# Altium schematic sheet Inputs.SchDoc(Inputs)
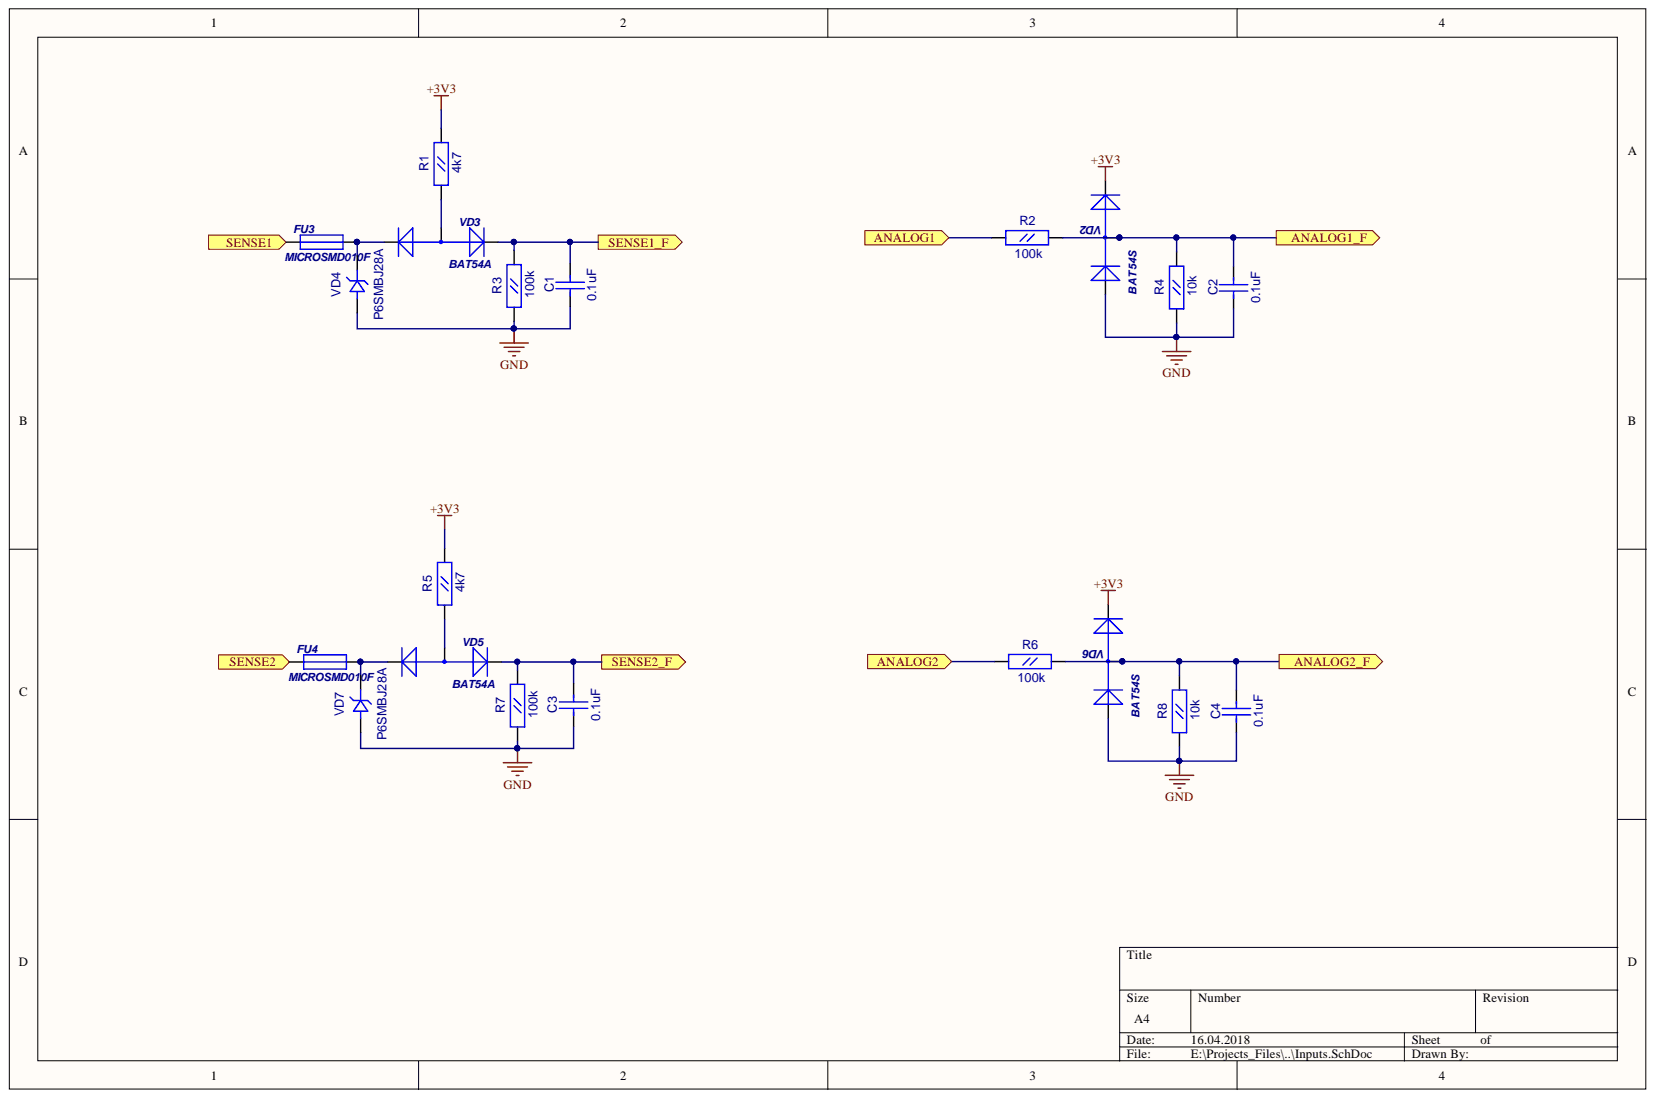
[diagram p0: Inputs.SchDoc]

## Parts
C1  0.1uF  [CC0603]
C2  0.1uF  [CC0603]
C3  0.1uF  [CC0603]
C4  0.1uF  [CC0603]
FU3  MICROSMD010F  [RC1210]
FU4  MICROSMD010F  [RC1210]
R1  4k7  [RC0603]
R2  100k  [RC0603]
R3  100k  [RC0603]
R4  10k  [RC0603]
R5  4k7  [RC0603]
R6  100k  [RC0603]
R7  100k  [RC0603]
R8  10k  [RC0603]
VD2  BAT54S  [SOT-23(GSD)]
VD3  BAT54A  [SOT-23(123)]
VD4  P6SMBJ28A  [SMB]
VD5  BAT54A  [SOT-23(123)]
VD6  BAT54S  [SOT-23(GSD)]
VD7  P6SMBJ28A  [SMB]

## Ports
ANALOG1, ANALOG1_F, ANALOG2, ANALOG2_F, SENSE1, SENSE1_F, SENSE2, SENSE2_F

## Nets
+3V3: R1.2 R5.2 VD2.2 VD6.2
GND: C1.1 C2.1 C3.1 C4.1 R3.1 R4.1 R7.1 R8.1 VD2.1 VD4.1 VD6.1 VD7.1
ANALOG1: R2.1 ; port ANALOG1
ANALOG1_F: C2.2 R2.2 R4.2 VD2.3 ; port ANALOG1_F
ANALOG2: R6.1 ; port ANALOG2
ANALOG2_F: C4.2 R6.2 R8.2 VD6.3 ; port ANALOG2_F
SENSE1: FU3.1 ; port SENSE1
SENSE1_F: C1.2 R3.2 VD3.1 ; port SENSE1_F
SENSE2: FU4.1 ; port SENSE2
SENSE2_F: C3.2 R7.2 VD5.1 ; port SENSE2_F
NetFU3_2: FU3.2 VD3.2 VD4.2
NetFU4_2: FU4.2 VD5.2 VD7.2
NetR1_1: R1.1 VD3.3
NetR5_1: R5.1 VD5.3

## Library data of the parts
C1-C4 = CC0603: Value: 0.1uF; Description: CC0603; Component Kind: Standard; Library Name: gprs_modem_2.SchLib
FU3 FU4 = MICROSMD: Value: MICROSMD010F; Description: MICROSMD; Component Kind: Standard; Library Name: gprs_modem_2.SchLib
R1-R8 = RC0603: Description: RC0603; Component Kind: Standard; Library Name: gprs_modem_2.SchLib
  R1 R5: Value: 4k7
  R2 R3 R6 R7: Value: 100k
  R4 R8: Value: 10k
VD2 VD6 = BAT54S: 1.0 Ampere General Purpose Rectifier; ComponentField6: Published 8-Jun-2000, Modified 11-July-2000: SIM Model Added.; Simulation: DIODE; Component Kind: Standard; Library Name: gprs_modem_2.SchLib
VD3 VD5 = BAT54A: 1.0 Ampere General Purpose Rectifier; ComponentField6: Published 8-Jun-2000, Modified 11-July-2000: SIM Model Added.; Simulation: DIODE; Component Kind: Standard; Library Name: gprs_modem_2.SchLib
VD4 VD7 = SMBJ: Value: P6SMBJ28A; Description: SMBJ; Component Kind: Standard; Library Name: gprs_modem_2.SchLib
